# Altium schematic sheet TS5_Power.SchDoc(TS5_Power)
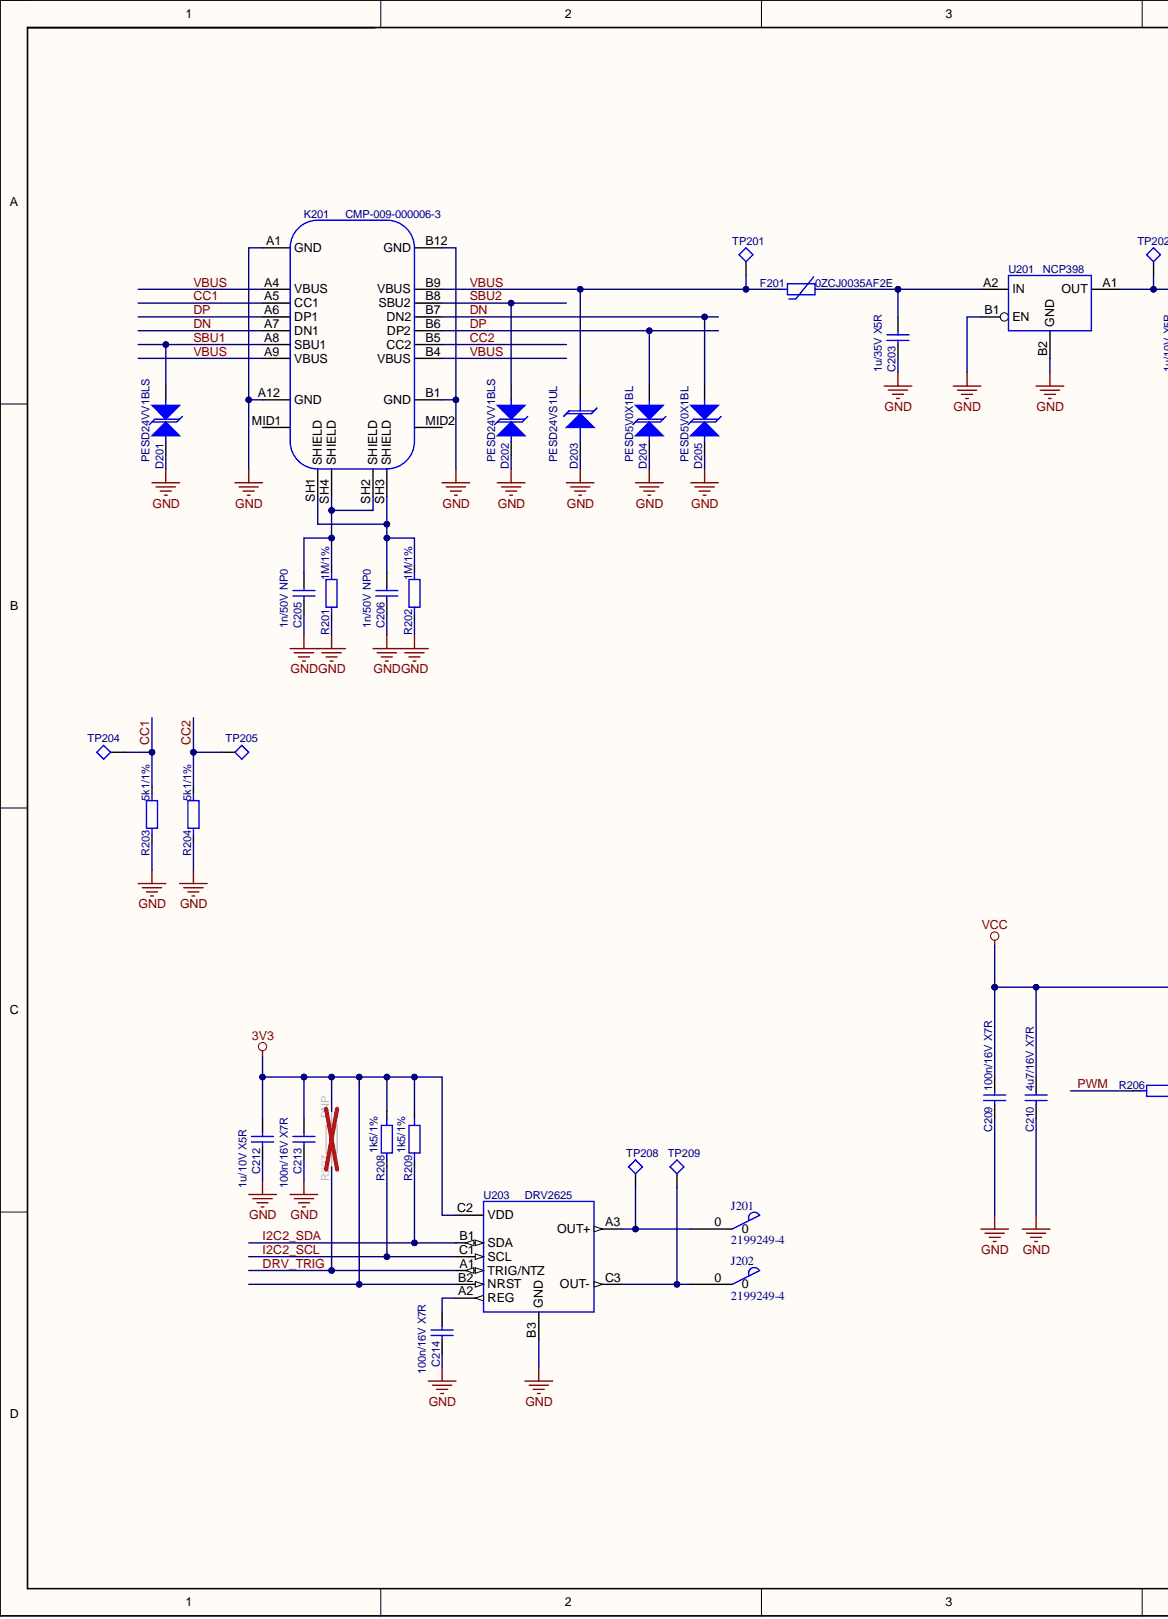
[diagram p0: TS5_Power.SchDoc, left]
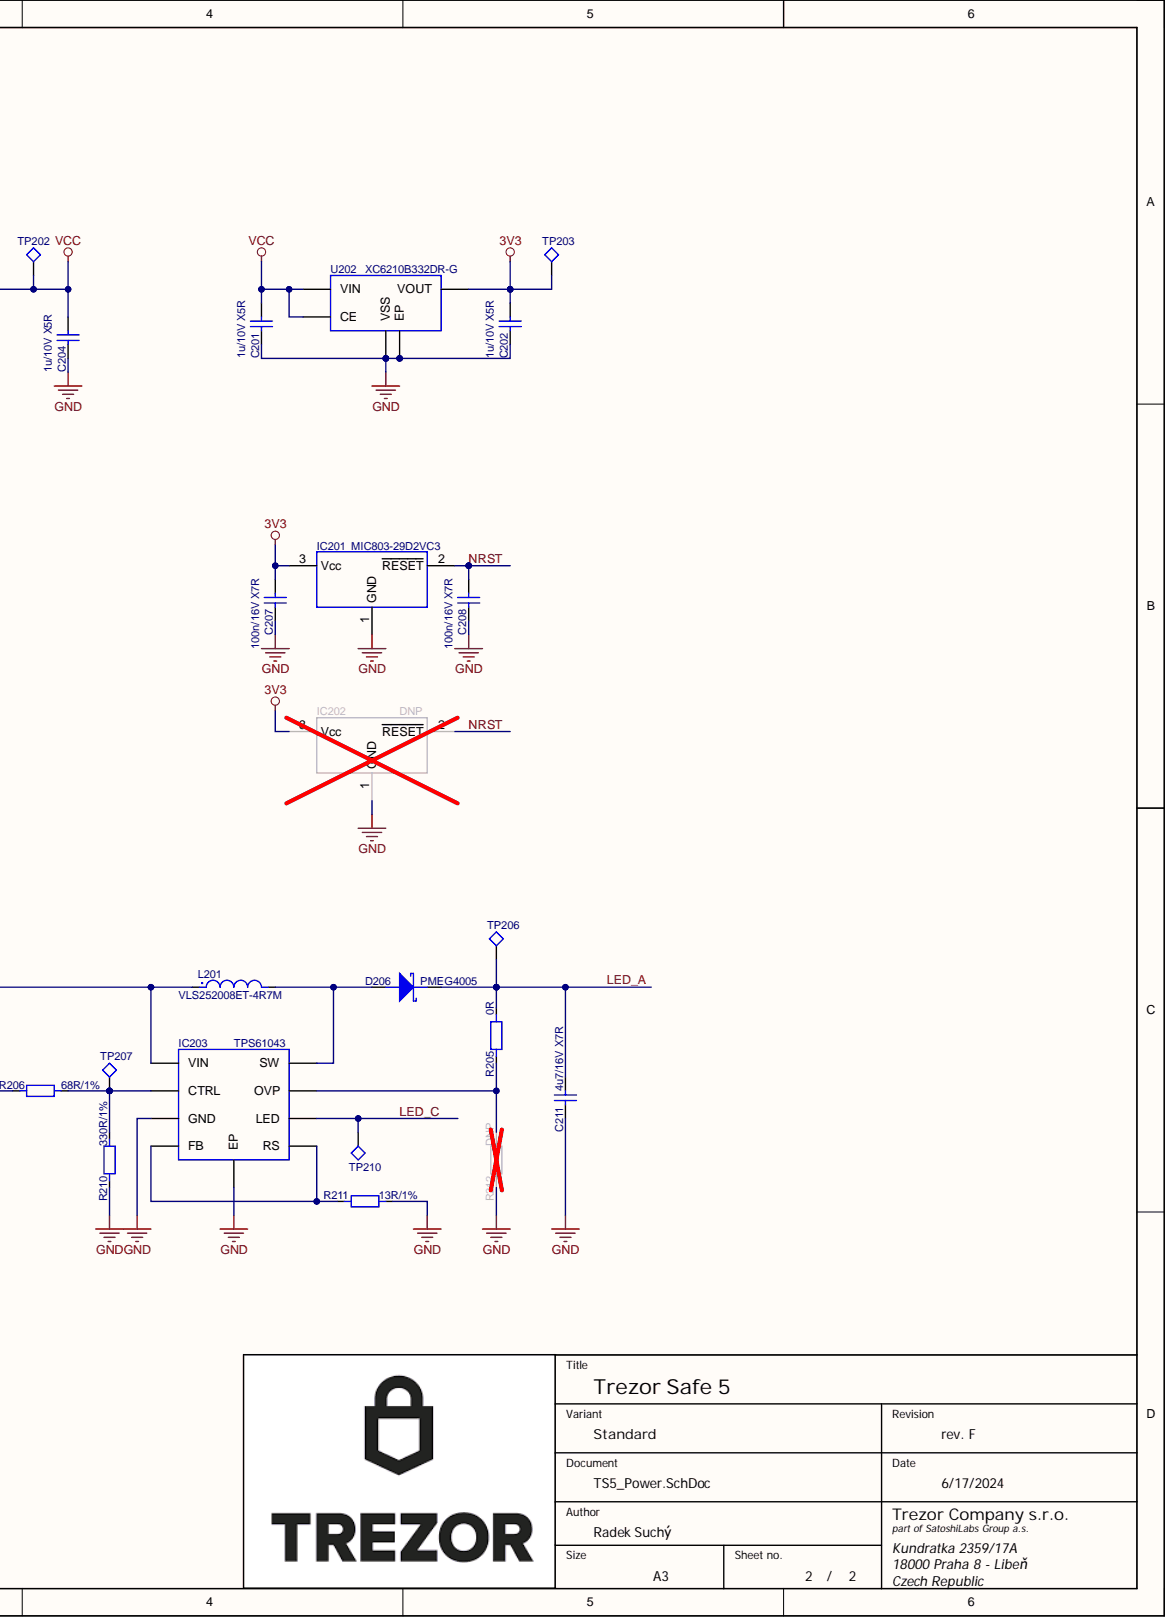
[diagram p1: TS5_Power.SchDoc, right]

## Parts
C201  1u/10V X5R  [C_0402_B]
C202  1u/10V X5R  [C_0402_B]
C203  1u/35V X5R  [C_0402_B]
C204  1u/10V X5R  [C_0402_B]
C205  1n/50V NP0  [C_0402_B]
C206  1n/50V NP0  [C_0402_B]
C207  100n/16V X7R  [C_0402_B]
C208  100n/16V X7R  [C_0402_B]
C209  100n/16V X7R  [C_0402_B]
C210  4u7/16V X7R  [C_0805_B]
C211  4u7/16V X7R  [C_0805_B]
C212  1u/10V X5R  [C_0402_B]
C213  100n/16V X7R  [C_0402_B]
C214  100n/16V X7R  [C_0402_B]
D201  PESD24VV1BLS  [SOD-882D_B]
D202  PESD24VV1BLS  [SOD-882D_B]
D203  PESD24VS1UL  [SOD-882_B]
D204  PESD5V0X1BL  [SOD-882D_B]
D205  PESD5V0X1BL  [SOD-882D_B]
D206  PMEG4005  [SOD-323FL_B]
F201  0ZCJ0035AF2E  [R_1206_B]
IC201  MIC803-29D2VC3  [SC-70-3_B]
IC202  DNP  [SOT-23-3_B]
IC203  TPS61043  [VSON-8_DRB_B]
J201  2199249-4  [2199249-4-Footprint-1]
J202  2199249-4  [2199249-4-Footprint-1]
K201  CMP-009-000006-3  [USB-C_3.1_CX90M-16P]
L201  VLS252008ET-4R7M  [L_2.5x2.0_B]
R201  1M/1%  [R_0402_B]
R202  1M/1%  [R_0402_B]
R203  5k1/1%  [R_0402_B]
R204  5k1/1%  [R_0402_B]
R205  0R  [R_0402_B]
R206  68R/1%  [R_0402_B]
R207  DNP  [R_0402_B]
R208  1k5/1%  [R_0402_B]
R209  1k5/1%  [R_0402_B]
R210  330R/1%  [R_0402_B]
R211  13R/1%  [R_0402_B]
R212  DNP  [R_0402_B]
TP201  Test_Point  [TP_1.5mm]  (value not drawn)  (no BOM)
TP202  Test_Point  [TP_1.5mm]  (value not drawn)  (no BOM)
TP203  Test_Point  [TP_1.5mm]  (value not drawn)  (no BOM)
TP204  Test_Point  [TP_1.5mm]  (value not drawn)  (no BOM)
TP205  Test_Point  [TP_1.5mm]  (value not drawn)  (no BOM)
TP206  Test_Point  [TP_1.5mm]  (value not drawn)  (no BOM)
TP207  Test_Point  [TP_1.5mm]  (value not drawn)  (no BOM)
TP208  Test_Point  [TP_1.5mm]  (value not drawn)  (no BOM)
TP209  Test_Point  [TP_1.5mm]  (value not drawn)  (no BOM)
TP210  Test_Point  [TP_1.5mm]  (value not drawn)  (no BOM)
U201  NCP398  [BGA-4_0.4mm_84X84X55_B]
U202  XC6210B332DR-G  [USP-6C_B]
U203  DRV2625  [BGA-9_1.47X1.47_B]

## Nets
3V3: C202.2 C207.2 C212.2 C213.2 IC201.3 IC202.3 R207.1 R208.1 R209.1 TP203.1 U202.5(VOUT) U203.B2(NRST) U203.C2(VDD)
GND: C201.1 C202.1 C203.1 C204.1 C205.1 C206.1 C207.1 C208.1 C209.1 C210.1 C211.1 C212.1 C213.1 C214.1 D201.A D202.A D203.A D204.A D205.A IC201.1 IC202.1 IC203.6(GND) IC203.EP K201.A1(GND) K201.A12(GND) K201.B1(GND) K201.B12(GND) R201.1 R202.1 R203.1 R204.1 R210.1 R211.1 R212.1 U201.B1(EN) U201.B2(GND) U202.4(VSS) U202.7(EP) U203.B3(GND)
VCC: C201.2 C204.2 C209.2 C210.2 IC203.3(VIN) L201.1 TP202.1 U201.A1(OUT) U202.1(CE) U202.3(VIN)
CC1: K201.A5(CC1) R203.2 TP204.1
CC2: K201.B5(CC2) R204.2 TP205.1
DN: D205.C K201.A7(DN1) K201.B7(DN2)
DP: D204.C K201.A6(DP1) K201.B6(DP2)
DRV_TRIG: R207.2 U203.A1(TRIG/NTZ)
I2C2_SCL: R208.2 U203.C1(SCL)
I2C2_SDA: R209.2 U203.B1(SDA)
LED_A: C211.2 D206.C R205.2 TP206.1
LED_C: IC203.1(LED) TP210.1
NRST: C208.2 IC201.2 IC202.2
PWM: R206.1
SBU1: D201.C K201.A8(SBU1)
SBU2: D202.C K201.B8(SBU2)
VBUS: D203.C F201.1 K201.A4(VBUS) K201.A9(VBUS) K201.B4(VBUS) K201.B9(VBUS) TP201.1
NetC203_2: C203.2 F201.2 U201.A2(IN)
NetC205_2: C205.2 K201.SH2(SHIELD) K201.SH4(SHIELD) R201.2
NetC206_2: C206.2 K201.SH1(SHIELD) K201.SH3(SHIELD) R202.2
NetC214_2: C214.2 U203.A2(REG)
NetD206_A: D206.A IC203.8(SW) L201.2
NetIC203_2: IC203.2(RS) IC203.4(FB) R211.2
NetIC203_5: IC203.5(CTRL) R206.2 R210.2 TP207.1
NetIC203_7: IC203.7(OVP) R205.1 R212.2
NetJ201_0: J201.0 TP208.1 U203.A3(OUT+)
NetJ202_0: J202.0 TP209.1 U203.C3(OUT-)

Not connected: K201 MID1 MID2

## Library data of the parts
C201 C202 C204 C212 = Capacitor: Man1: Taiyo Yuden; PN1: LMK105BJ105KVHF; RegNo: P+CSM-034.10; Value: 1u; AddParam: /10V X5R; Component Kind: Standard; Package: C_0402_B; Technology: SMT
C203 = Capacitor: Value: 1u; AddParam: /35V X5R; Component Kind: Standard; Package: C_0402_B; Technology: SMT
C205 C206 = Capacitor: RegNo: SAT+CSM-336.00; Value: 1n; AddParam: /50V NP0; Component Kind: Standard; Package: C_0402_B; Technology: SMT
C207-C209 C213 C214 = Capacitor: RegNo: SAT+CSM-109.00; Value: 100n; AddParam: /16V X7R; Component Kind: Standard; Package: C_0402_B; Technology: SMT
C210 C211 = Capacitor: RegNo: SAT+CSM-099.05; Value: 4u7; AddParam: /16V X7R; Component Kind: Standard; Package: C_0805_B; Technology: SMT
D201 D202 = TVS_Bid: Component Kind: Standard; Package: SOD-882D_B; Technology: SMT; Value: PESD24VV1BLS
D203 = TVS_Uni: Component Kind: Standard; Package: SOD-882_B; Technology: SMT/THT; Value: PESD24VS1UL
D204 D205 = TVS_Bid: RegNo: P+DIP-152.62; Component Kind: Standard; Package: SOD-882D_B; Technology: SMT; Value: PESD5V0X1BL
D206 = Schottky: RegNo: SAT+DIP-136.10; Component Kind: Standard; Package: SOD-323FL_B; PN1: PMEG4005; Technology: SMT; Value: PMEG4005
F201 = Polyswitch: Man1: Bel Fuse; Component Kind: Standard; Package: R_1206_B; PN1: 0ZCJ0035AF2E; Technology: SMT; Value: 0ZCJ0035AF2E
IC201 = MIC803: Supervisor Circuit with Open-Drain Reset Output; RegNo: SAT+IO-221.30; Component Kind: Standard; ComponentLink1Description: Datasheet; ComponentLink1URL: http://ww1.microchip.com/downloads/en/DeviceDoc/mic803.pdf; Package: SC-70-3_B; Technology: SMT; Value: MIC803-29D2VC3
IC202 = MIC803: Supervisor Circuit with Open-Drain Reset Output; Man1: Microchip; PN1: MIC803-29D2VM3; Component Kind: Standard; ComponentLink1Description: Datasheet; ComponentLink1URL: http://ww1.microchip.com/downloads/en/DeviceDoc/mic803.pdf; Package: SOT-23-3_B; Technology: SMT; Value: DNP
IC203 = TPS61043: Constant Current LED Driver; RegNo: SAT+IO-216.20; Component Kind: Standard; Package: VSON-8_DRB_B; Technology: SMT; Value: TPS61043
J201 J202 = CMP-00002-1: Connector Accessories Spring Finger Type B Connector Contacts Phosphor Bronze T/R; Current Rating: 2A; Plating: Gold; Plating Thickness: 0.5um; Preloaded: No; Component Kind: Standard; Contact Plating: Gold; Lead Free: Lead Free; Material: Bronze; Mounting Technology: Solder; Packaging: Tape and Reel; Reach SVHC Compliant: Unknown; Series: -; Width: 1.5mm; Library Name: Trezor Company s.r.o.
K201 = CMP-009-000006-3: USB type C - 16pin - 20V, 6A  -40°C +85°C; Manufacturer: Hirose Connector; MPN: CX90M-16P; Gender: Receptacle; Mating Cycles: 10000; Number of Ports: 1; Shielding: Shielded; Value: CX90M-16P; Component Kind: Standard; Connector Type: USB; Contact Plating: Gold; Design Item ID: USB3.1_C_16pin; Flammability Rating: UL94 V-0; Insulation Color: Black; Max Operating Temperature: 85°C; Min Operating Temperature: -30°C; Mounting Technology: Surface Mount,Through Hole,Edge,Board,Horizontal; Package: USB-C_3.1_CX90M-16P; Packaging: Cut Tape; RoHS Compliant: Yes; Series: CX; Source: TS5_1.SCHLIB; Technology: SMT; Termination: Solder; Library Name: Trezor Company s.r.o.
L201 = Inductor: RegNo: P+LT-027.05; Component Kind: Standard; Package: L_2.5x2.0_B; PN1: VLS252008ET-4R7M; Technology: SMT; Value: VLS252008ET-4R7M
R201 R202 = Resistor: AddParam: /1%; RegNo: SAT+RSM-272.00; Value: 1M; Component Kind: Standard; Package: R_0402_B; Technology: SMT
R203 R204 = Resistor: AddParam: /1%; RegNo: SAT+RSM-145.20; Value: 5k1; Component Kind: Standard; Package: R_0402_B; Technology: SMT
R205 = Resistor: RegNo: SAT+RSM-190.00; Component Kind: Standard; Package: R_0402_B; Technology: SMT; Value: 0R
R206 = Resistor: AddParam: /1%; RegNo: SAT+RSM-222.00; Value: 68R; Component Kind: Standard; Package: R_0402_B; Technology: SMT
R207 R212 = Resistor: Component Kind: Standard; Package: R_0402_B; Technology: SMT; Value: DNP
R208 R209 = CMP-007-000000-3: SMD Resistor; Component Kind: Standard; Design Item ID: Resistor; Package: R_0402_B; Resistor Type: Thick Film; RoHS Compliant: Yes; Source: TS5_1.SCHLIB; Technology: SMT; Value: 1k5/1%; Library Name: Trezor Company s.r.o.
R210 = Resistor: AddParam: /1%; RegNo: SAT+RSM-230.00; Value: 330R; Component Kind: Standard; Package: R_0402_B; Technology: SMT
R211 = Resistor: AddParam: /1%; Value: 13R; Component Kind: Standard; Package: R_0402_B; Technology: SMT
TP201-TP210 = CMP-008-000002-1: Design Item ID: Test_Point; Package: TP_1.5mm; Source: TS5_1.SCHLIB; Technology: SMT/THT; Library Name: Trezor Company s.r.o.
U201 = CMP-006-000001-3: USB Type-C VCONN  Overvoltage Protection IC, 2,4V - 28V  -40°C +85°C  WLCSP-4; Manufacturer: onsemi; MPN: NCP398FCCT1G; Value: NCP398FCCT1G; Component Kind: Standard; Design Item ID: NCP398; Package: BGA-4_0.4mm_84X84X55_B; Source: TS5_1.SCHLIB; Technology: SMT; Library Name: Trezor Company s.r.o.
U202 = XC6210xxxxDR-G: High Current, High Speed LDO Regulator; Man1: Torex; Component Kind: Standard; Package: USP-6C_B; PN1: XC6210B332DR-G; Technology: SMT; Value: XC6210B332DR-G
U203 = CMP-009-000001-4: LRA/ERM Haptic Drive  with waveform library, auto-resonance tracking, & low power consumption  BGA9 - 0,4mm pitch; Manufacturer: Texas Instruments; MPN: DRV2625YFF; Value: DRV2625YFF; Component Kind: Standard; Design Item ID: DRV2625; Package: BGA-9_1.47X1.47_B; Technology: SMT; Library Name: Trezor Company s.r.o.
